# Altium schematic sheet Atmega 328p.SchDoc("Atmega 328p")
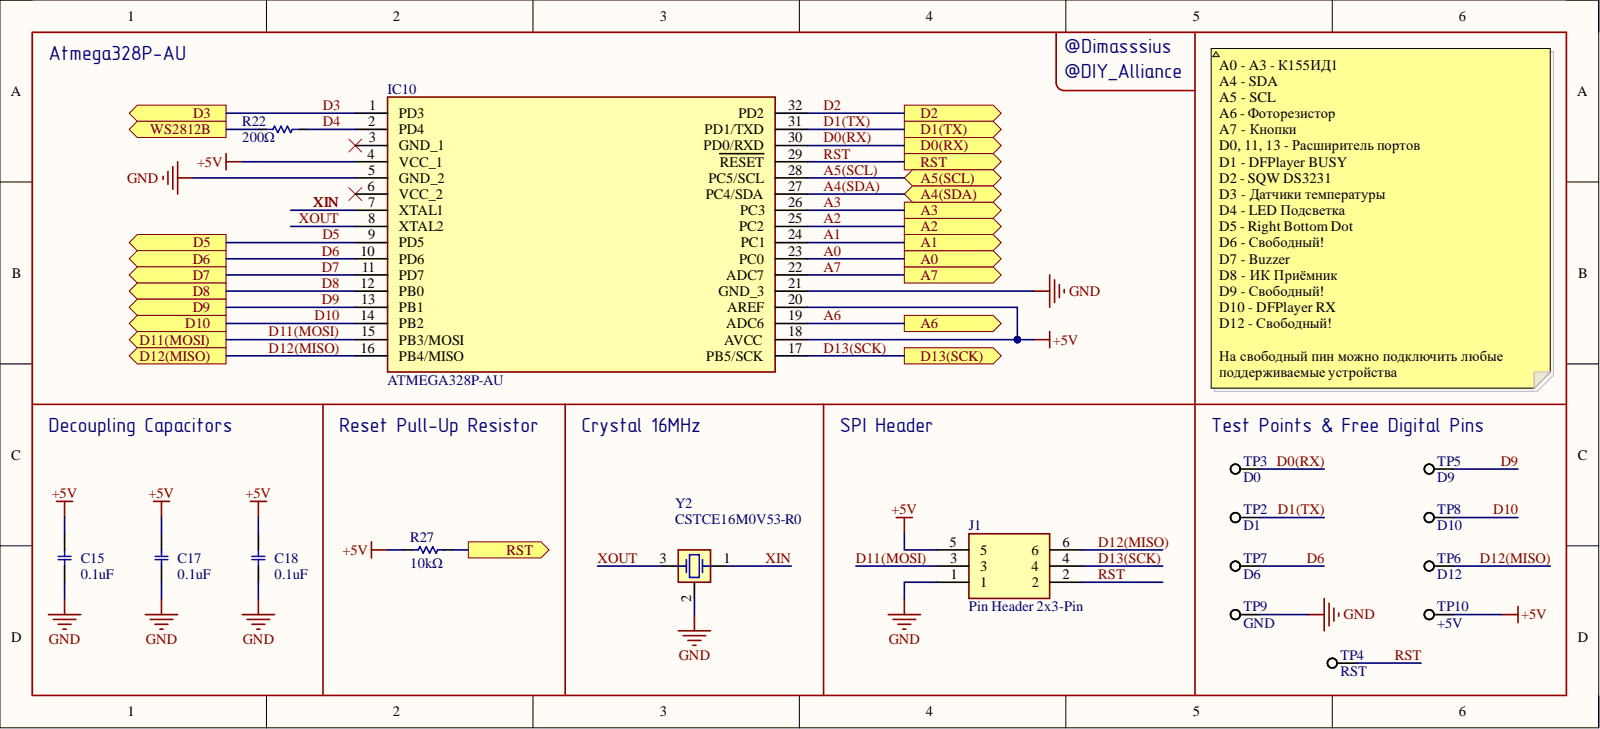
[diagram p0: Atmega 328p.SchDoc]

## Parts
C15  0.1uF  [CAP_SMD_MLCC_C0603_(M1608)_C0402A_(M1005A)]
C17  0.1uF  [CAP_SMD_MLCC_C0603_(M1608)_C0402A_(M1005A)]
C18  0.1uF  [CAP_SMD_MLCC_C0603_(M1608)_C0402A_(M1005A)]
IC10  ATMEGA328P-AU  [QFP80P900X900X120-32N WITH SPI INTERFACE 2.54]
R22  200Ω  [RES_SMD_R0603_(M1608)_R0402A_(M1005A)]
R27  10kΩ  [RES_SMD_R0603_(M1608)_R0402A_(M1005A)]
TP2  D1  [Test Point]  (no BOM)
TP3  D0  [Test Point]  (no BOM)
TP4  RST  [Test Point]  (no BOM)
TP5  D9  [Test Point]  (no BOM)
TP6  D12  [Test Point]  (no BOM)
TP7  D6  [Test Point]  (no BOM)
TP8  D10  [Test Point]  (no BOM)
TP9  GND  [Test Point]  (no BOM)
TP10  +5V  [Test Point]  (no BOM)
Y2  CSTCE16M0V53-R0  [XTL_3_3213]

## Ports
A0, A1, A2, A3, A4(SDA), A5(SCL), A6, A7, D0(RX), D1(TX), D2, D3, D5, D6, D7, D8, D9, D10, D11(MOSI), D12(MISO), D13(SCK), RST, WS2812B

## Nets
+5V: C15.1 C17.2 C18.2 IC10.4(VCC_1) IC10.18(AVCC) IC10.20(AREF) R27.2 TP10.1
GND: C15.2 C17.1 C18.1 IC10.5(GND_2) IC10.21(GND_3) TP9.1 Y2.2
A0: IC10.23(PC0) ; port A0
A1: IC10.24(PC1) ; port A1
A2: IC10.25(PC2) ; port A2
A3: IC10.26(PC3) ; port A3
A4(SDA): IC10.27(PC4/SDA) ; port A4(SDA)
A5(SCL): IC10.28(PC5/SCL) ; port A5(SCL)
A6: IC10.19(ADC6) ; port A6
A7: IC10.22(ADC7) ; port A7
D0(RX): IC10.30(PD0/RXD) TP3.1 ; port D0(RX)
D1(TX): IC10.31(PD1/TXD) TP2.1 ; port D1(TX)
D2: IC10.32(PD2) ; port D2
D3: IC10.1(PD3) ; port D3
D4: IC10.2(PD4) R22.2
D5: IC10.9(PD5) ; port D5
D6: IC10.10(PD6) TP7.1 ; port D6
D7: IC10.11(PD7) ; port D7
D8: IC10.12(PB0) ; port D8
D9: IC10.13(PB1) TP5.1 ; port D9
D10: IC10.14(PB2) TP8.1 ; port D10
D11(MOSI): IC10.15(PB3/MOSI) ; port D11(MOSI)
D12(MISO): IC10.16(PB4/MISO) TP6.1 ; port D12(MISO)
D13(SCK): IC10.17(PB5/SCK) ; port D13(SCK)
RST: IC10.29(RESET) R27.1 TP4.1 ; port RST
WS2812B: R22.1 ; port WS2812B
XIN: IC10.7(XTAL1) Y2.1
XOUT: IC10.8(XTAL2) Y2.3

Not connected: IC10 3(GND_1) 6(VCC_2)

## Library data of the parts
C15 C17 C18 = CAP_SMD_MLCC_0.1UF_6.3V: Capacitor, Ceramic (MLCC), SMD, 100nF, 6.3V, ±10%, X7R, Multilayer Ceramic Capacitors MLCC - SMD/SMT, ROHS; Manufacturer: Murata Electronics North America; Part Number: LLM315R71C104MA11L; @ qty: 0; Minimum Quantity: 1; Tolerance: ±20%; Unit Price (USD): 0.9; Voltage: 16V; Applications: Bypass, Decoupling; Component Kind: Standard; Datasheets: http://www.murata.com/~/media/webrenewal/support/library/catalog/products/capacitor/mlcc/c02e.pdf; Digi-Key Part Number: 490-4495-1-ND; Factory Stock: 0; Features: Low ESL (Multi-Terminal); Height - Seated (Max): -; Image: //media.digikey.com/Renders/Murata%20Renders/1206(LLM315).jpg; Lead Spacing: -; Lead Style: -; Library Name: Capacitors.SchLib; Mounting Type: Surface Mount, MLCC; Operating Temperature: -55°C ~ 125°C; Package / Case: -; Packaging: Cut Tape (CT); Part Status: Active; Quantity Available: 2915; Ratings: -; Series: LLM; Size / Dimension: -; Temperature Coefficient: X7R; Thickness (Max): -; Value: 0.1uF
IC10 = ATMEGA328P-AU: Microcontroller, TQFP-32(7x7), AVR, 20MHz, Microcontrollers (MCU/MPU/SOC), ROHS; Component Kind: Standard; Datasheet Link: https://componentsearchengine.com/Datasheets/2/ATMEGA328P-AU.pdf; Height: 1.2mm; Library Name: SamacSys.SchLib; Value: ATMEGA328P-AU
R22 = RES_SMD_200Ω: Resistor, Thick Film Resistor, SMD, 200Ω, ±5%, Chip Resistor - Surface Mount, ROHS; Component Kind: Standard; Library Name: Resistors.SchLib; Value: 200Ω
R27 = RES_SMD_10KΩ: Resistor, Thick Film Resistor, SMD, 10kΩ, ±5%, Chip Resistor - Surface Mount, ROHS; Component Kind: Standard; Library Name: Resistors.SchLib; Value: 10kΩ
TP2-TP10 = Test Point: Description: Test Point; Library Name: SamacSys.SchLib
  TP2: Value: D1
  TP3: Value: D0
  TP4: Value: RST
  TP5: Value: D9
  TP6: Value: D12
  TP7: Value: D6
  TP8: Value: D10
  TP9: Value: GND
  TP10: Value: +5V
Y2 = CSTCE16M0V53-R0: Ceramic Resonators, SMD, SMD3213-3P, 3.2x1.3mm,16MHz, 15pF, 40Ω, Ceramic Resonators, ROHS; Component Kind: Standard; Datasheet Link: https://www.murata.com/en-sg/products/productdetail?partno=CSTCE16M0V53-R0; Height: 1mm; Library Name: SamacSys.SchLib; Value: CSTCE16M0V53-R0
